# Altium schematic sheet CUK_3Ph_Analog_Isolation.SchDoc
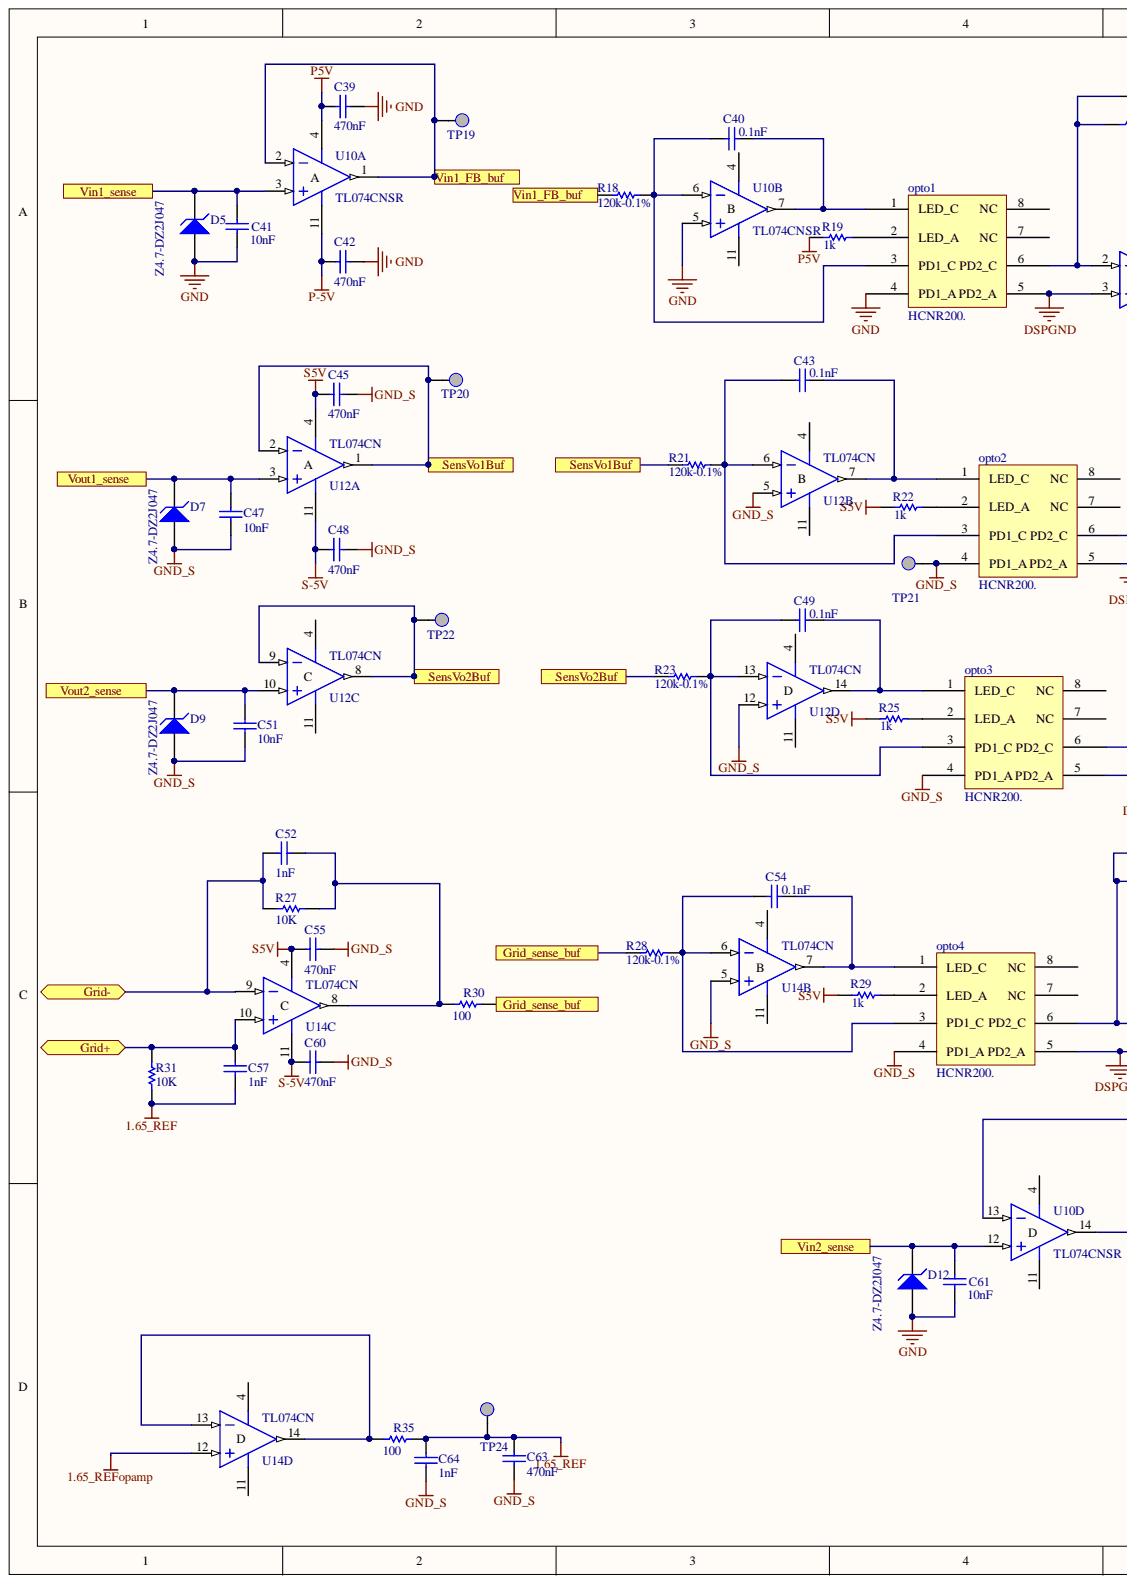
[diagram p0: CUK_3Ph_Analog_Isolation.SchDoc, left]
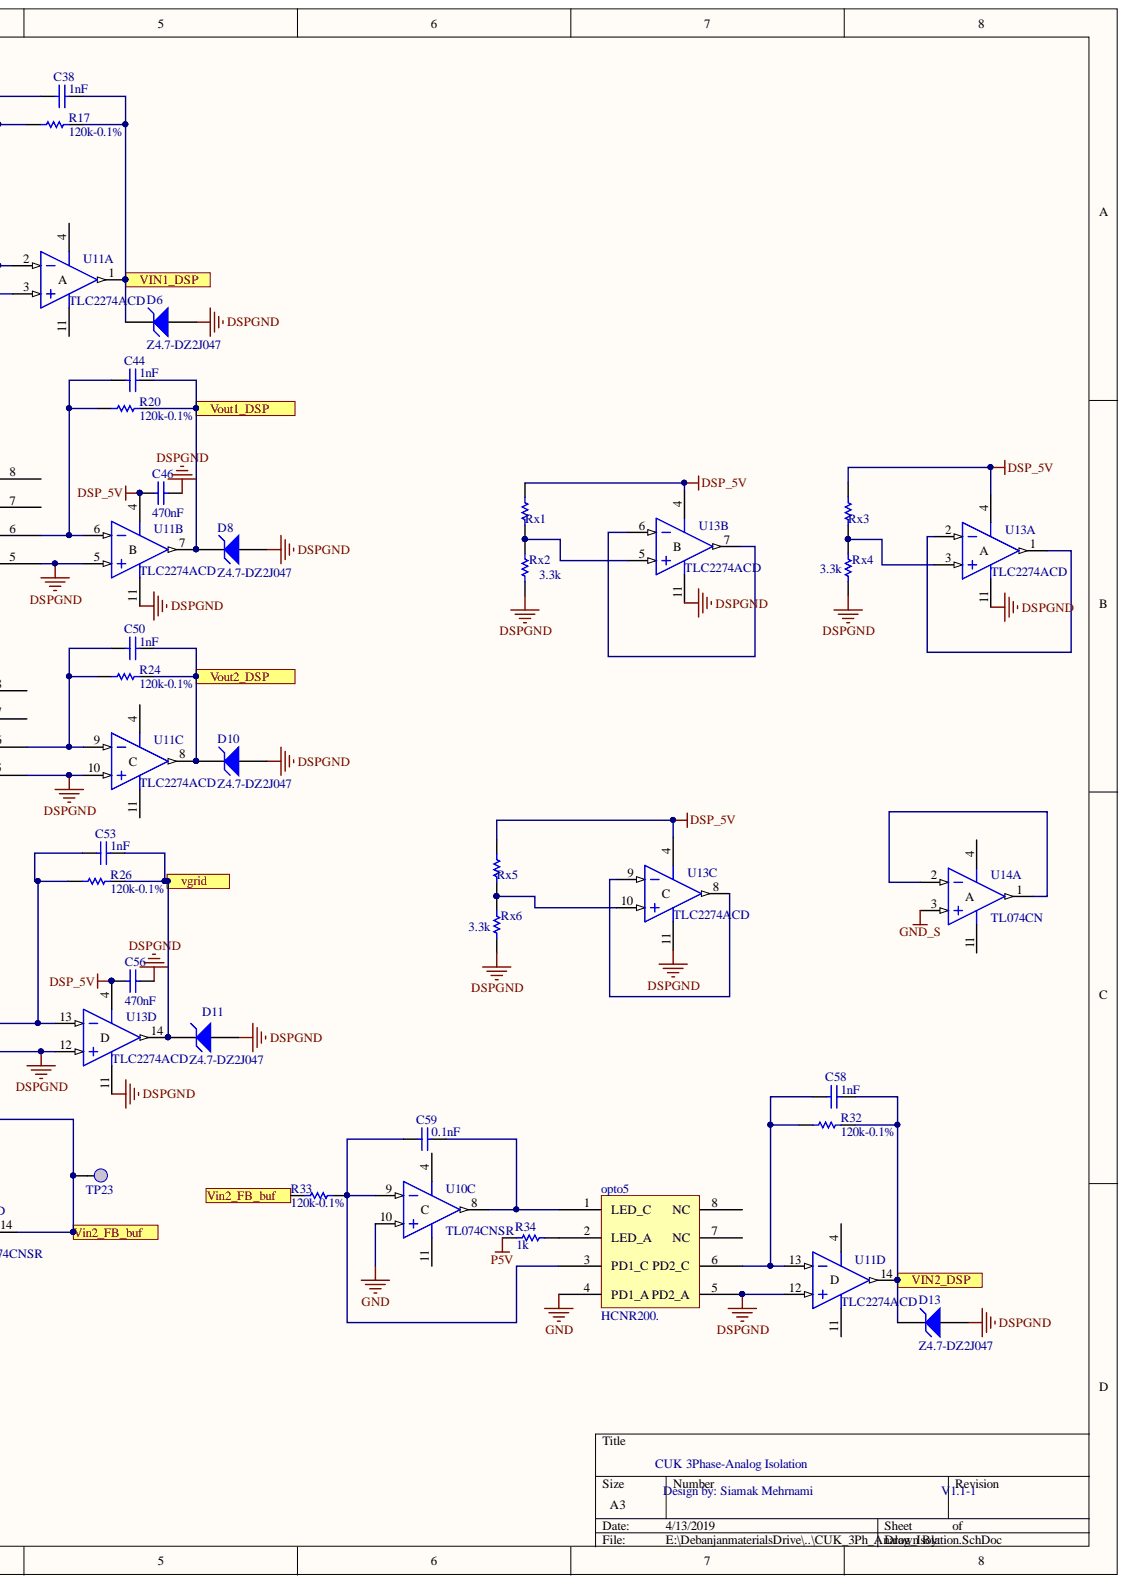
[diagram p1: CUK_3Ph_Analog_Isolation.SchDoc, right]

## Parts
C38  value 1nF  [Capacitor 0805]  (hidden comment: Cap)
C39  value 470nF  [Capacitor 0805]  (hidden comment: Cap)
C40  value 0.1nF  [Capacitor 0805]  (hidden comment: Cap)
C41  value 10nF  [Capacitor 0805]  (hidden comment: Cap)
C42  value 470nF  [Capacitor 0805]  (hidden comment: Cap)
C43  value 0.1nF  [Capacitor 0805]  (hidden comment: Cap)
C44  value 1nF  [Capacitor 0805]  (hidden comment: Cap)
C45  value 470nF  [Capacitor 0805]  (hidden comment: Cap)
C46  value 470nF  [Capacitor 0805]  (hidden comment: Cap)
C47  value 10nF  [Capacitor 0805]  (hidden comment: Cap)
C48  value 470nF  [Capacitor 0805]  (hidden comment: Cap)
C49  value 0.1nF  [Capacitor 0805]  (hidden comment: Cap)
C50  value 1nF  [Capacitor 0805]  (hidden comment: Cap)
C51  value 10nF  [Capacitor 0805]  (hidden comment: Cap)
C52  value 1nF  [Capacitor 0805]  (hidden comment: Cap)
C53  value 1nF  [Capacitor 0805]  (hidden comment: Cap)
C54  value 0.1nF  [Capacitor 0805]  (hidden comment: Cap)
C55  value 470nF  [Capacitor 0805]  (hidden comment: Cap)
C56  value 470nF  [Capacitor 0805]  (hidden comment: Cap)
C57  value 1nF  [Capacitor 0805]  (hidden comment: Cap)
C58  value 1nF  [Capacitor 0805]  (hidden comment: Cap)
C59  value 0.1nF  [Capacitor 0805]  (hidden comment: Cap)
C60  value 470nF  [Capacitor 0805]  (hidden comment: Cap)
C61  value 10nF  [Capacitor 0805]  (hidden comment: Cap)
C63  value 470nF  [Capacitor 0805]  (hidden comment: Cap)
C64  value 1nF  [Capacitor 0805]  (hidden comment: Cap)
D5  Z4.7-DZ2J047  [Zener DZ2J047]
D6  Z4.7-DZ2J047  [Zener DZ2J047]
D7  Z4.7-DZ2J047  [Zener DZ2J047]
D8  Z4.7-DZ2J047  [Zener DZ2J047]
D9  Z4.7-DZ2J047  [Zener DZ2J047]
D10  Z4.7-DZ2J047  [Zener DZ2J047]
D11  Z4.7-DZ2J047  [Zener DZ2J047]
D12  Z4.7-DZ2J047  [Zener DZ2J047]
D13  Z4.7-DZ2J047  [Zener DZ2J047]
opto1  HCNR200.  [HCNR200]
opto2  HCNR200.  [HCNR200]
opto3  HCNR200.  [HCNR200]
opto4  HCNR200.  [HCNR200]
opto5  HCNR200.  [HCNR200]
R17  value 120k-0.1%  [0805 RES]  (hidden comment: Res1)
R18  value 120k-0.1%  [0805 RES]  (hidden comment: Res1)
R19  value 1k  [0805 RES]  (hidden comment: Res1)
R20  value 120k-0.1%  [0805 RES]  (hidden comment: Res1)
R21  value 120k-0.1%  [0805 RES]  (hidden comment: Res1)
R22  value 1k  [0805 RES]  (hidden comment: Res1)
R23  value 120k-0.1%  [0805 RES]  (hidden comment: Res1)
R24  value 120k-0.1%  [0805 RES]  (hidden comment: Res1)
R25  value 1k  [0805 RES]  (hidden comment: Res1)
R26  value 120k-0.1%  [0805 RES]  (hidden comment: Res1)
R27  value 10K  [0805 RES]  (hidden comment: Res1)
R28  value 120k-0.1%  [0805 RES]  (hidden comment: Res1)
R29  value 1k  [0805 RES]  (hidden comment: Res1)
R30  value 100  [0805 RES]  (hidden comment: Res1)
R31  value 10K  [0805 RES]  (hidden comment: Res1)
R32  value 120k-0.1%  [0805 RES]  (hidden comment: Res1)
R33  value 120k-0.1%  [0805 RES]  (hidden comment: Res1)
R34  value 1k  [0805 RES]  (hidden comment: Res1)
R35  value 100  [0805 RES]  (hidden comment: Res1)
Rx1  Res1  [0805 RES]  (value not drawn)
Rx2  value 3.3k  [0805 RES]  (hidden comment: Res1)
Rx3  Res1  [0805 RES]  (value not drawn)
Rx4  value 3.3k  [0805 RES]  (hidden comment: Res1)
Rx5  Res1  [0805 RES]  (value not drawn)
Rx6  value 3.3k  [0805 RES]  (hidden comment: Res1)
TP19  Test point  [Test Point]  (value not drawn)
TP20  Test point  [Test Point]  (value not drawn)
TP21  Test point  [Test Point]  (value not drawn)
TP22  Test point  [Test Point]  (value not drawn)
TP23  Test point  [Test Point]  (value not drawn)
TP24  Test point  [Test Point]  (value not drawn)
U10  TL074CNSR  [DIP14]  (parts A,B,C,D)
U11  TLC2274ACD  [SOIC14]  (parts A,B,C,D)
U12  TL074CN  [DIP14]  (parts A,B,C,D)
U13  TLC2274ACD  [SOIC14]  (parts A,B,C,D)
U14  TL074CN  [DIP14]  (parts A,B,C,D)

## Ports
Grid+, Grid-, Grid_sense_buf, SensVo1Buf, SensVo2Buf, vgrid, VIN1_DSP, Vin1_FB_buf, Vin1_sense, VIN2_DSP, Vin2_FB_buf, Vin2_sense, Vout1_DSP, Vout1_sense, Vout2_DSP, Vout2_sense

## Nets
1.65_REF: C57.2 C63.1 C64.1 R31.1 R35.1 TP24.1
1.65_REFopamp: U14.12
DSP_5V: C46.2 C56.2 Rx1.2 Rx3.2 Rx5.2 U11.4 U13.4
DSPGND: C46.1 C56.1 D6.1 D8.1 D10.1 D11.1 D13.1 opto1.5(PD2_A) opto2.5(PD2_A) opto3.5(PD2_A) opto4.5(PD2_A) opto5.5(PD2_A) Rx2.1 Rx4.1 Rx6.1 U11.3 U11.5 U11.10 U11.11 U11.12 U13.11 U13.12
GND: C39.1 C41.2 C42.1 C61.2 D5.1 D12.1 opto1.4(PD1_A) opto5.4(PD1_A) U10.5 U10.10
GND_S: C45.1 C47.2 C48.1 C51.2 C55.1 C60.1 C63.2 C64.2 D7.1 D9.1 opto2.4(PD1_A) opto3.4(PD1_A) opto4.4(PD1_A) TP21.1 U12.5 U12.12 U14.3 U14.5
P5V: C39.2 R19.1 R34.1 U10.4
P-5V: C42.2 U10.11
S5V: C45.2 C55.2 R22.1 R25.1 R29.1 U12.4 U14.4
S-5V: C48.2 C60.2 U12.11 U14.11
Grid_sense_buf: R28.1 R30.1 ; port Grid_sense_buf
SensVo1Buf: R21.1 TP20.1 U12.1 U12.2 ; port SensVo1Buf
SensVo2Buf: R23.1 TP22.1 U12.8 U12.9 ; port SensVo2Buf
vgrid: C53.2 D11.2 R26.2 U13.14 ; port vgrid
VIN1_DSP: C38.2 D6.2 R17.2 U11.1 ; port VIN1_DSP
Vin1_FB_buf: R18.1 TP19.1 U10.1 U10.2 ; port Vin1_FB_buf
Vin1_sense: C41.1 D5.2 U10.3 ; port Vin1_sense
VIN2_DSP: C58.2 D13.2 R32.2 U11.14 ; port VIN2_DSP
Vin2_FB_buf: R33.1 TP23.1 U10.13 U10.14 ; port Vin2_FB_buf
Vin2_sense: C61.1 D12.2 U10.12 ; port Vin2_sense
Vout1_DSP: C44.2 D8.2 R20.2 U11.7 ; port Vout1_DSP
Vout1_sense: C47.1 D7.2 U12.3 ; port Vout1_sense
Vout2_DSP: C50.2 D10.2 R24.2 U11.8 ; port Vout2_DSP
Vout2_sense: C51.1 D9.2 U12.10 ; port Vout2_sense
NetC38_1: C38.1 opto1.6(PD2_C) R17.1 U11.2
NetC40_1: C40.1 opto1.3(PD1_C) R18.2 U10.6
NetC40_2: C40.2 opto1.1(LED_C) U10.7
NetC43_1: C43.1 opto2.3(PD1_C) R21.2 U12.6
NetC43_2: C43.2 opto2.1(LED_C) U12.7
NetC44_1: C44.1 opto2.6(PD2_C) R20.1 U11.6
NetC49_1: C49.1 opto3.3(PD1_C) R23.2 U12.13
NetC49_2: C49.2 opto3.1(LED_C) U12.14
NetC50_1: C50.1 opto3.6(PD2_C) R24.1 U11.9
NetC52_1: C52.1 R27.2 U14.9
NetC52_2: C52.2 R27.1 R30.2 U14.8
NetC53_1: C53.1 opto4.6(PD2_C) R26.1 U13.13
NetC54_1: C54.1 opto4.3(PD1_C) R28.2 U14.6
NetC54_2: C54.2 opto4.1(LED_C) U14.7
NetC57_1: C57.1 R31.2 U14.10
NetC58_1: C58.1 opto5.6(PD2_C) R32.1 U11.13
NetC59_1: C59.1 opto5.3(PD1_C) R33.2 U10.9
NetC59_2: C59.2 opto5.1(LED_C) U10.8
Netopto1_2: opto1.2(LED_A) R19.2
Netopto2_2: opto2.2(LED_A) R22.2
Netopto3_2: opto3.2(LED_A) R25.2
Netopto4_2: opto4.2(LED_A) R29.2
Netopto5_2: opto5.2(LED_A) R34.2
NetR35_2: R35.2 U14.13 U14.14
NetRx1_1: Rx1.1 Rx2.2 U13.5
NetRx3_1: Rx3.1 Rx4.2 U13.3
NetRx5_1: Rx5.1 Rx6.2 U13.10
NetU13_1: U13.1 U13.2
NetU13_6: U13.6 U13.7
NetU13_8: U13.8 U13.9
NetU14_1: U14.1 U14.2

Not connected: opto1 7(NC) 8(NC); opto2 7(NC) 8(NC); opto3 7(NC) 8(NC); opto4 7(NC) 8(NC); opto5 7(NC) 8(NC)

## Library data of the parts
C38-C61 C63 C64 = Cap: Capacitor; Component Kind: Standard; LatestRevisionDate: 17-Jul-2002; LatestRevisionNote: Re-released for DXP Platform.; Library Name: Miscellaneous Devices.IntLib; PackageReference: RAD-0.3; Published: 8-Jun-2000; Signal Integrity: Cap; Simulation: CAP; Publisher: Altium Limited
D5-D13 = D Zener: Zener Diode; Component Kind: Standard; LatestRevisionDate: 17-Jul-2002; LatestRevisionNote: Re-released for DXP Platform.; Library Name: Miscellaneous Devices.IntLib; PackageReference: DIODE-0.7; Published: 8-Jun-2000; Signal Integrity: D Zener; Simulation: ZENER; Publisher: Altium Limited
opto1-opto5 = Component_1: Component Kind: Standard; Library Name: HCRN200.SchLib
R17-R35 Rx1-Rx6 = Res1: Resistor; Component Kind: Standard; Published: 8-Jun-2000; Signal Integrity: Res; Simulation: RESISTOR; Publisher: Altium Limited
  R17-R35: LatestRevisionDate: 17-Jul-2002; LatestRevisionNote: Re-released for DXP Platform.; Library Name: Miscellaneous Devices.IntLib; PackageReference: AXIAL-0.3
  Rx1-Rx6: Library Name: control Board.SchLib; Revision: July-2002: Re-released for DXP Platform.
U10 U12 U14 = TL074J: Low-Noise JFET-Input Operational Amplifier; Component Kind: Standard; ComponentLink1Description: Manufacturer Link; ComponentLink1URL: http://www.ti.com/; ComponentLink2Description: Datasheet; ComponentLink2URL: http://www-s.ti.com/sc/ds/tl074.pdf; DatasheetVersion: Aug-1996; LatestRevisionDate: 04-Mar-2005; LatestRevisionNote: Stylized 3D Model Added.; Library Name: TI Operational Amplifier.IntLib; PackageDescription: DIP; 14 Leads; Row Spacing 7.62 mm; Pitch 2.54 mm; PackageReference: J014; PackageVersion: Jun-1999; PCB3D: J014; Published: 16-Jan-2001; Simulation: TL074; Publisher: Altium Limited
U11 U13 = TS27L4AMD: Very Low-Power CMOS Quad Operational Amplifier; Code_IPC: SOIC127P600-14; Component Kind: Standard; ComponentLink1Description: Manufacturer Link; ComponentLink1URL: http://www.st.com/; ComponentLink2Description: Datasheet; ComponentLink2URL: http://www.st.com/stonline/products/literature/ds/2305/ts27l4.pdf; DatasheetVersion: Nov-1998; LatestRevisionDate: 13-Apr-2006; LatestRevisionNote: IPC-7351 Footprint Added.; Library Name: ST Operational Amplifier.IntLib; PackageDescription: 14-Pin Small Outline Integrated Circuit 1.27 mm Pitch; PackageReference: SO14; PackageVersion: Sep-1998; PCB3D: SO14; Published: 24-Aug-2001; Publisher: Altium Limited
TP19-TP24 = Test point: Component Kind: Standard
  TP19-TP23: Library Name: CUK1.SchLib
  TP24: Library Name: control Board.SchLib
